# Altium schematic sheet SEG7.SchDoc
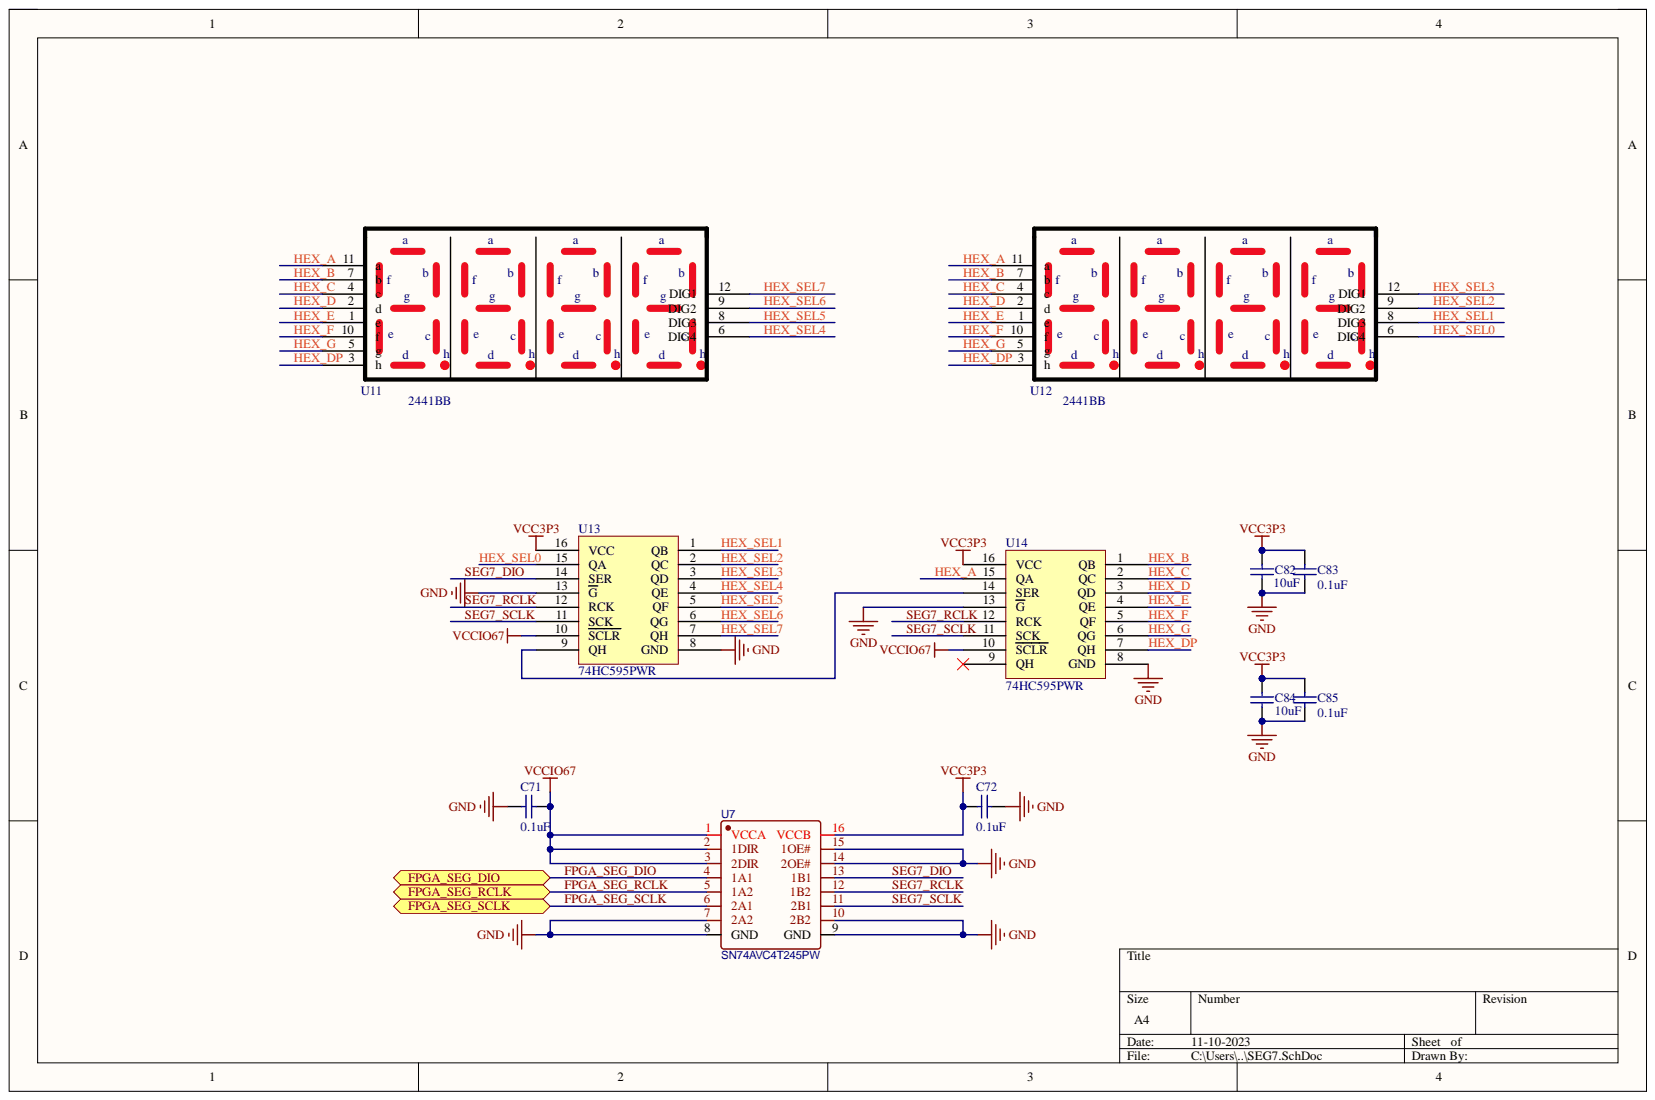
[diagram p0: SEG7.SchDoc]

## Parts
C71  0.1uF  [C0603]
C72  0.1uF  [C0603]
C82  10uF  [C0805]
C83  0.1uF  [C0402]
C84  10uF  [C0805]
C85  0.1uF  [C0402]
U7  SN74AVC4T245PW  [tssop16-26-173]
U11  2441BB  [LED-SEG-TH_SLR0224CR5C1BD-3_5]
U12  2441BB  [LED-SEG-TH_SLR0224CR5C1BD-3_5]
U13  74HC595PWR  [TSSOP-16]
U14  74HC595PWR  [TSSOP-16]

## Ports
FPGA_SEG_DIO, FPGA_SEG_RCLK, FPGA_SEG_SCLK

## Nets
GND: C71.2 C72.1 C82.2 C83.2 C84.2 C85.2 U7.7(2A2) U7.8(GND) U7.9(GND) U7.10(2B2) U7.14(2OE#) U7.15(1OE#) U13.8(GND) U13.13(G) U14.8(GND) U14.13(G)
VCC3P3: C72.2 C82.1 C83.1 C84.1 C85.1 U7.16(VCCB) U13.16(VCC) U14.16(VCC)
VCCIO67: C71.1 U7.1(VCCA) U7.2(1DIR) U7.3(2DIR) U13.10(SCLR) U14.10(SCLR)
FPGA_SEG_DIO: U7.4(1A1) ; port FPGA_SEG_DIO
FPGA_SEG_RCLK: U7.5(1A2) ; port FPGA_SEG_RCLK
FPGA_SEG_SCLK: U7.6(2A1) ; port FPGA_SEG_SCLK
HEX_A: U11.11(a) U12.11(a) U14.15(QA)
HEX_B: U11.7(b) U12.7(b) U14.1(QB)
HEX_C: U11.4(c) U12.4(c) U14.2(QC)
HEX_D: U11.2(d) U12.2(d) U14.3(QD)
HEX_DP: U11.3(h) U12.3(h) U14.7(QH)
HEX_E: U11.1(e) U12.1(e) U14.4(QE)
HEX_F: U11.10(f) U12.10(f) U14.5(QF)
HEX_G: U11.5(g) U12.5(g) U14.6(QG)
HEX_SEL0: U12.6(DIG4) U13.15(QA)
HEX_SEL1: U12.8(DIG3) U13.1(QB)
HEX_SEL2: U12.9(DIG2) U13.2(QC)
HEX_SEL3: U12.12(g_DIG1) U13.3(QD)
HEX_SEL4: U11.6(DIG4) U13.4(QE)
HEX_SEL5: U11.8(DIG3) U13.5(QF)
HEX_SEL6: U11.9(DIG2) U13.6(QG)
HEX_SEL7: U11.12(g_DIG1) U13.7(QH)
SEG7_DIO: U7.13(1B1) U13.14(SER)
SEG7_RCLK: U7.12(1B2) U13.12(RCK) U14.12(RCK)
SEG7_SCLK: U7.11(2B1) U13.11(SCK) U14.11(SCK)
NetU13_9: U13.9(QH) U14.14(SER)

Not connected: U14 9(QH)

## Library data of the parts
C71 C72 = Cap_1: Capacitor; Component Kind: Standard; LatestRevisionDate: 17-Jul-2002; LatestRevisionNote: Re-released for DXP Platform.; Library Name: zynq.SCHLIB; PackageDescription: Radial Cap, Thru-Hole; 2 Leads; 0.3 in Pin Spacing; PackageReference: RAD-0.3; Published: 8-Jun-2000; Signal Integrity: Cap; Simulation: CAP; Value: 0.1uF; Publisher: Altium Limited
C82-C85 = Cap Semi: Capacitor (Semiconductor SIM Model); Component Kind: Standard; LatestRevisionDate: 29-May-2009; LatestRevisionNote: IPC-7351 Footprint Added.; Library Name: NEW.SchLib; PackageDescription: Chip Capacitor; PackageReference: C1206; Published: 8-Jun-2000; Publisher: Altium Limited
  C82 C84: Value: 10uf
  C83 C85: Value: 0.1uF
U7 = SN74AVC4T245PW: BOM_Manufacturer: TI(德州仪器); Contributor: LCSC; BOM_JLCPCB Part Class: Extended Part; BOM_Manufacturer Part: SN74AVC4T245PW; BOM_Supplier: LCSC; BOM_Supplier Part: C1523275; Component Kind: Standard; Description: SN74AVC4T245PW; Library Name: zynq.SCHLIB; spicePre: U; spiceSymbolName: SN74AVC4T245PW
U11 = smg_6*8: 3.6寸4位共阳极数码管; Component Kind: Standard; Library Name: 数码管.SCHLIB
U12 = smg_6*8: Component Kind: Standard; Library Name: 数码管.SCHLIB
U13 U14 = 74hc595: Component Kind: Standard; Library Name: NEW.SchLib
